# Altium schematic sheet ATSAM4E16EA_AU Main Controller.SchDoc
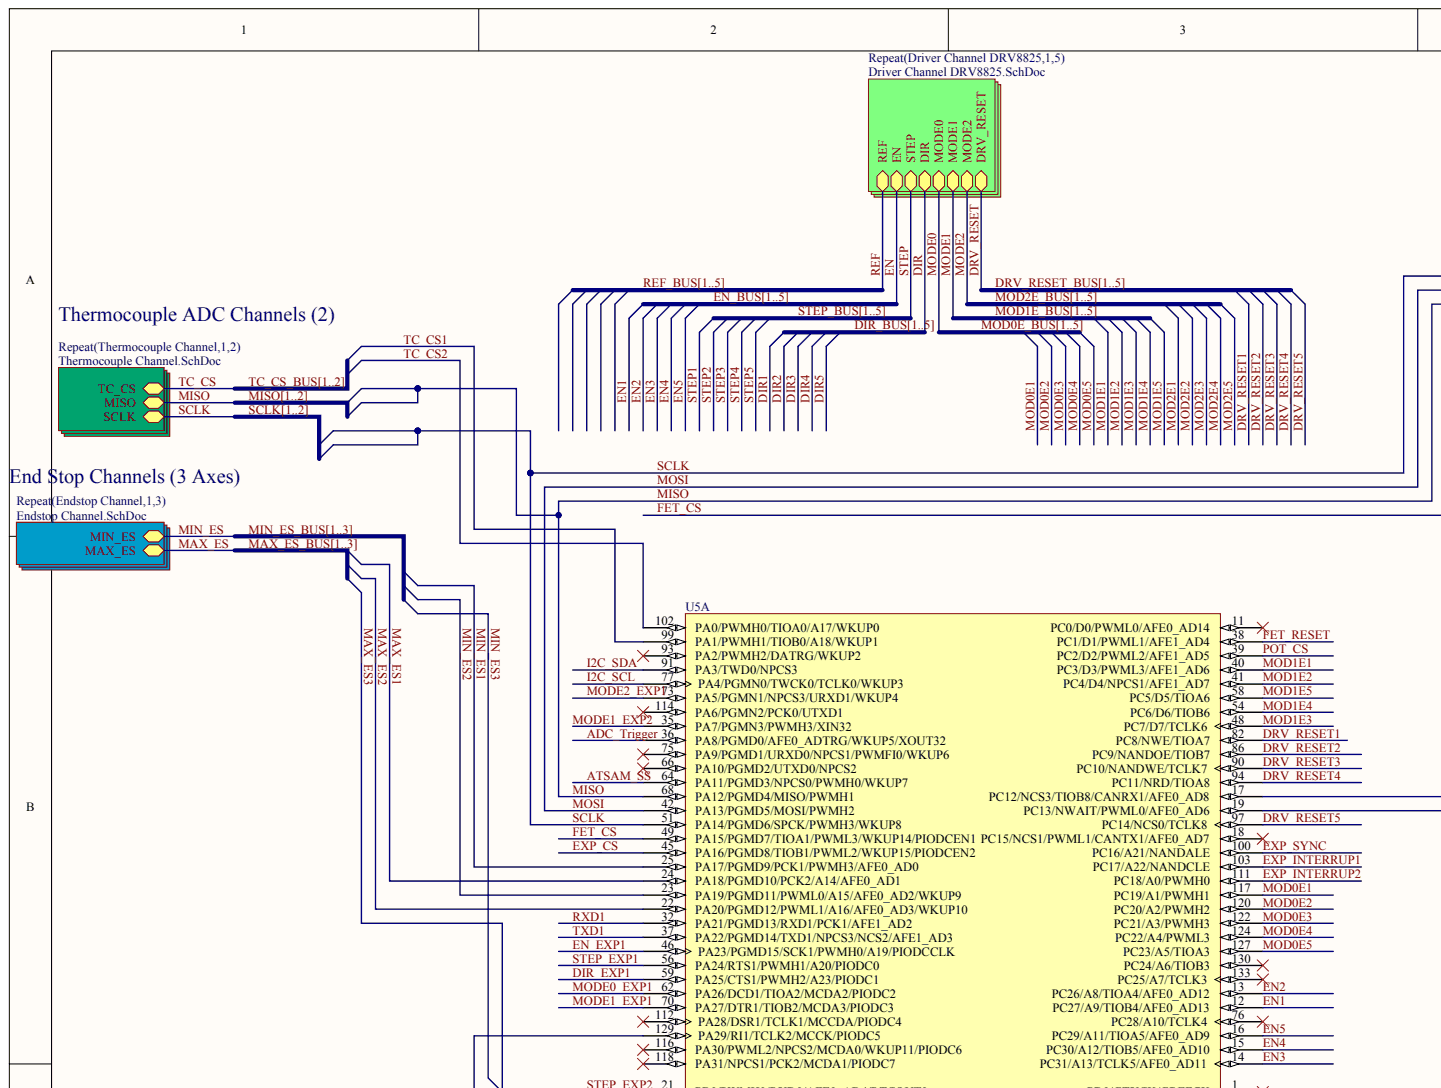
[diagram p0: ATSAM4E16EA_AU Main Controller.SchDoc, top left]
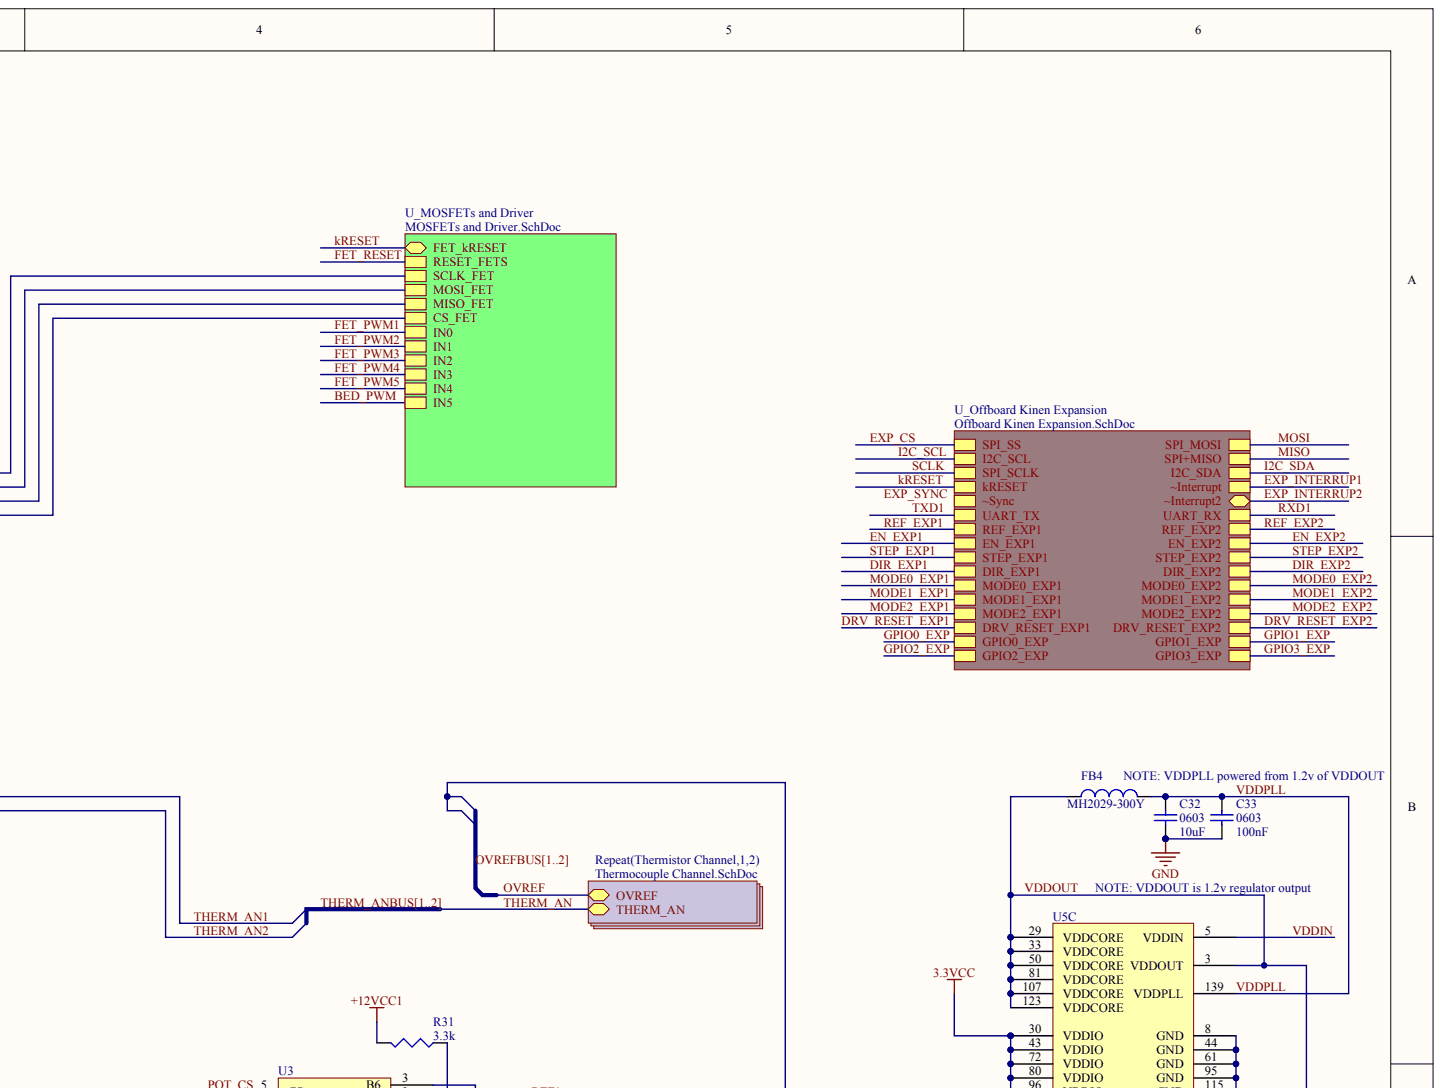
[diagram p1: ATSAM4E16EA_AU Main Controller.SchDoc, top right]
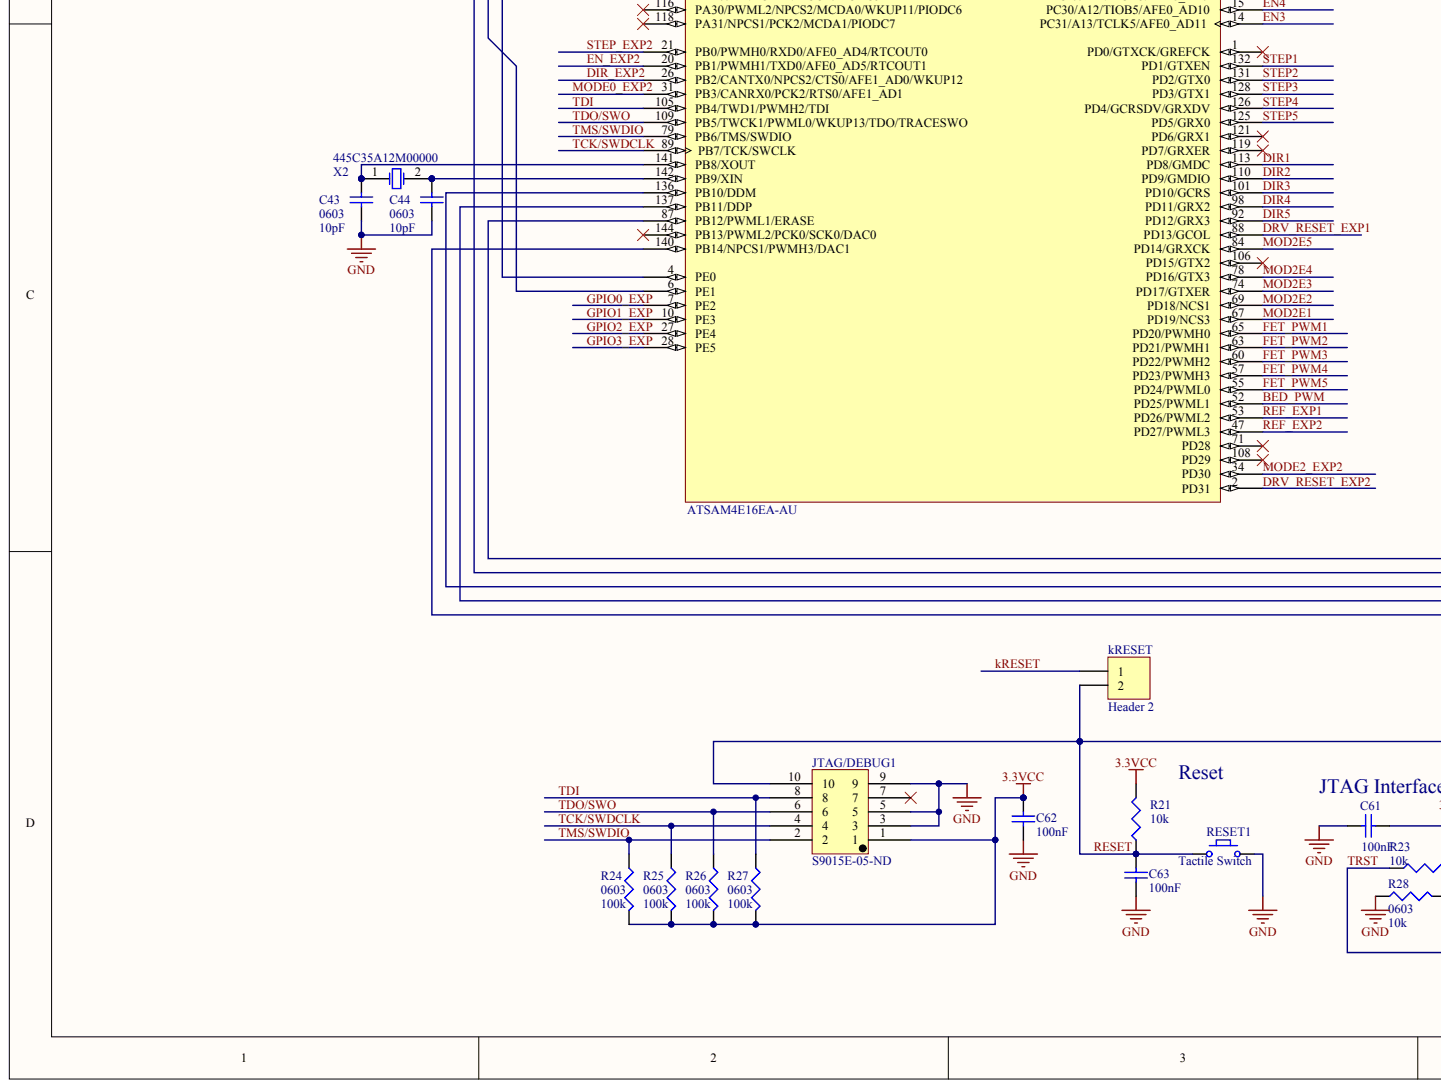
[diagram p2: ATSAM4E16EA_AU Main Controller.SchDoc, bottom left]
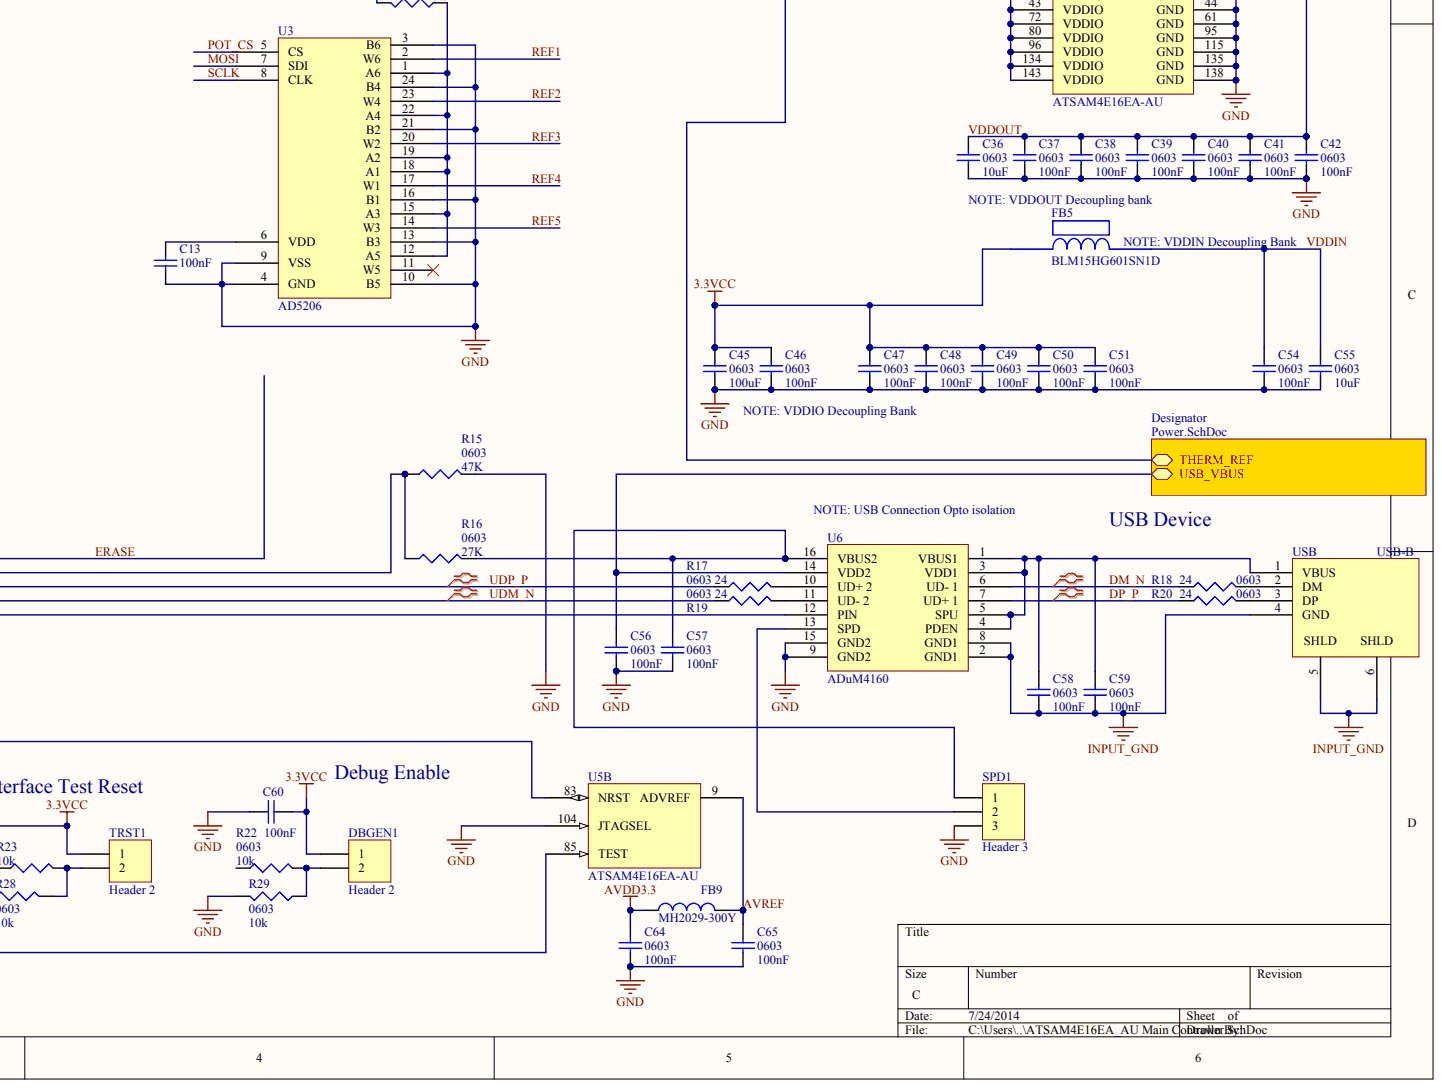
[diagram p3: ATSAM4E16EA_AU Main Controller.SchDoc, bottom right]

## Parts
C13  value 100nF  (hidden comment: Cap Semi)
C32  0603  value 10uF
C33  0603  value 100nF
C36  0603  value 10uF
C37  0603  value 100nF
C38  0603  value 100nF
C39  0603  value 100nF
C40  0603  value 100nF
C41  0603  value 100nF
C42  0603  value 100nF
C43  0603  value 10pF
C44  0603  value 10pF
C45  0603  value 100uF
C46  0603  value 100nF
C47  0603  value 100nF
C48  0603  value 100nF
C49  0603  value 100nF
C50  0603  value 100nF
C51  0603  value 100nF
C54  0603  value 100nF
C55  0603  value 10uF
C56  0603  value 100nF
C57  0603  value 100nF
C58  0603  value 100nF
C59  0603  value 100nF
C60  value 100nF  (hidden comment: Cap Semi)
C61  value 100nF  (hidden comment: Cap Semi)
C62  value 100nF  (hidden comment: Cap Semi)
C63  value 100nF  (hidden comment: Cap Semi)
C64  0603  value 100nF
C65  0603  value 100nF
DBGEN1  Header 2
FB4  MH2029-300Y
FB5  BLM15HG601SN1D
FB9  MH2029-300Y
JTAG/DEBUG1  S9015E-05-ND
kRESET  Header 2
R15  0603  value 47K
R16  0603  value 27K
R17  0603  value 24
R18  0603  value 24
R19  0603  value 24
R20  0603  value 24
R21  value 10k  (hidden comment: Res3)
R22  0603  value 10k
R23  value 10k  (hidden comment: Res3)
R24  0603  value 100k
R25  0603  value 100k
R26  0603  value 100k
R27  0603  value 100k
R28  0603  value 10k
R29  0603  value 10k
R31  value 3.3k  (hidden comment: Res3)
RESET1  Tactile Switch
SPD1  Header 3
TRST1  Header 2
U3  AD5206
U5  ATSAM4E16EA-AU  (parts A,B,C)
U6  ADuM4160
USB  USB-B
X2  445C35A12M00000

## Nets
3.3VCC: C45.2 C46.2 C47.2 C48.2 C49.2 C50.2 C51.2 C60.2 C61.2 C62.2 DBGEN1.1 FB5.1 JTAG/DEBUG1.1 R21.2 R24.2 R25.2 R26.2 R27.2 TRST1.1 U5.30(VDDIO) U5.43(VDDIO) U5.72(VDDIO) U5.80(VDDIO) U5.96(VDDIO) U5.134(VDDIO) U5.143(VDDIO)
+12VCC1: R31.2
AVDD3.3: C64.2 FB9.1
GND: C13.1 C32.1 C33.1 C36.1 C37.1 C38.1 C39.1 C40.1 C41.1 C42.1 C43.1 C44.1 C45.1 C46.1 C47.1 C48.1 C49.1 C50.1 C51.1 C54.1 C55.1 C56.1 C57.1 C60.1 C61.1 C62.1 C63.1 C64.1 C65.1 JTAG/DEBUG1.3 JTAG/DEBUG1.5 JTAG/DEBUG1.9 R15.2 R28.1 R29.2 RESET1.2 SPD1.3 U3.3(B6) U3.4(GND) U3.9(VSS) U3.10(B5) U3.13(B3) U3.16(B1) U3.21(B2) U3.24(B4) U5.8(GND) U5.44(GND) U5.61(GND) U5.95(GND) U5.104(JTAGSEL) U5.115(GND) U5.135(GND) U5.138(GND) U6.9(GND2) U6.15(GND2)
INPUT_GND: C58.1 C59.1 U6.2(GND1) U6.8(GND1) USB.4(GND) USB.5 USB.6
ADC_TRIGGER: U5.36(PA8/PGMD0/AFE0_ADTRG/WKUP5/XOUT32)
ATSAM_SS: U5.64(PA11/PGMD3/NPCS0/PWMH0/WKUP7)
AVREF: C65.2 FB9.2 U5.9(ADVREF)
BED_PWM: U5.52(PD25/PWML1)
DIR1: U5.113(PD8/GMDC)
DIR2: U5.110(PD9/GMDIO)
DIR3: U5.101(PD10/GCRS)
DIR4: U5.98(PD11/GRX2)
DIR5: U5.92(PD12/GRX3)
DIR_EXP1: U5.59(PA25/CTS1/PWMH2/A23/PIODC1)
DIR_EXP2: U5.26(PB2/CANTX0/NPCS2/CTS0/AFE1_AD0/WKUP12)
DM_N: R18.1 U6.6(UD-_1)
DP_P: R20.1 U6.7(UD+_1)
DRV_RESET1: U5.82(PC8/NWE/TIOA7)
DRV_RESET2: U5.86(PC9/NANDOE/TIOB7)
DRV_RESET3: U5.90(PC10/NANDWE/TCLK7)
DRV_RESET4: U5.94(PC11/NRD/TIOA8)
DRV_RESET5: U5.97(PC14/NCS0/TCLK8)
DRV_RESET_EXP1: U5.88(PD13/GCOL)
DRV_RESET_EXP2: U5.2(PD31)
EN1: U5.12(PC27/A9/TIOB4/AFE0_AD13)
EN2: U5.13(PC26/A8/TIOA4/AFE0_AD12)
EN3: U5.14(PC31/A13/TCLK5/AFE0_AD11)
EN4: U5.15(PC30/A12/TIOB5/AFE0_AD10)
EN5: U5.16(PC29/A11/TIOA5/AFE0_AD9)
EN_EXP1: U5.46(PA23/PGMD15/SCK1/PWMH0/A19/PIODCCLK)
EN_EXP2: U5.20(PB1/PWMH1/TXD0/AFE0_AD5/RTCOUT1)
ERASE: U5.87(PB12/PWML1/ERASE)
EXP_CS: U5.45(PA16/PGMD8/TIOB1/PWML2/WKUP15/PIODCEN2)
EXP_INTERRUP1: U5.103(PC17/A22/NANDCLE)
EXP_INTERRUP2: U5.111(PC18/A0/PWMH0)
EXP_SYNC: U5.100(PC16/A21/NANDALE)
FET_CS: U5.49(PA15/PGMD7/TIOA1/PWML3/WKUP14/PIODCEN1)
FET_PWM1: U5.65(PD20/PWMH0)
FET_PWM2: U5.63(PD21/PWMH1)
FET_PWM3: U5.60(PD22/PWMH2)
FET_PWM4: U5.57(PD23/PWMH3)
FET_PWM5: U5.55(PD24/PWML0)
FET_RESET: U5.38(PC1/D1/PWML1/AFE1_AD4)
GPIO0_EXP: U5.7(PE2)
GPIO1_EXP: U5.10(PE3)
GPIO2_EXP: U5.27(PE4)
GPIO3_EXP: U5.28(PE5)
I2C_SCL: U5.77(PA4/PGMN0/TWCK0/TCLK0/WKUP3)
I2C_SDA: U5.91(PA3/TWD0/NPCS3)
KRESET: kRESET.1
MAX_ES1: U5.24(PA18/PGMD10/PCK2/A14/AFE0_AD1)
MAX_ES2: U5.22(PA20/PGMD12/PWML1/A16/AFE0_AD3/WKUP10)
MAX_ES3: U5.4(PE0)
MIN_ES1: U5.25(PA17/PGMD9/PCK1/PWMH3/AFE0_AD0)
MIN_ES2: U5.23(PA19/PGMD11/PWML0/A15/AFE0_AD2/WKUP9)
MIN_ES3: U5.6(PE1)
MISO: U5.68(PA12/PGMD4/MISO/PWMH1)
MOD0E1: U5.117(PC19/A1/PWMH1)
MOD0E2: U5.120(PC20/A2/PWMH2)
MOD0E3: U5.122(PC21/A3/PWMH3)
MOD0E4: U5.124(PC22/A4/PWML3)
MOD0E5: U5.127(PC23/A5/TIOA3)
MOD1E1: U5.40(PC3/D3/PWML3/AFE1_AD6)
MOD1E2: U5.41(PC4/D4/NPCS1/AFE1_AD7)
MOD1E3: U5.48(PC7/D7/TCLK6)
MOD1E4: U5.54(PC6/D6/TIOB6)
MOD1E5: U5.58(PC5/D5/TIOA6)
MOD2E1: U5.67(PD19/NCS3)
MOD2E2: U5.69(PD18/NCS1)
MOD2E3: U5.74(PD17/GTXER)
MOD2E4: U5.78(PD16/GTX3)
MOD2E5: U5.84(PD14/GRXCK)
MODE0_EXP1: U5.62(PA26/DCD1/TIOA2/MCDA2/PIODC2)
MODE0_EXP2: U5.31(PB3/CANRX0/PCK2/RTS0/AFE1_AD1)
MODE1_EXP1: U5.70(PA27/DTR1/TIOB2/MCDA3/PIODC3)
MODE1_EXP2: U5.35(PA7/PGMN3/PWMH3/XIN32)
MODE2_EXP1: U5.73(PA5/PGMN1/NPCS3/URXD1/WKUP4)
MODE2_EXP2: U5.34(PD30)
MOSI: U3.7(SDI) U5.42(PA13/PGMD5/MOSI/PWMH2)
POT_CS: U3.5(CS) U5.39(PC2/D2/PWML2/AFE1_AD5)
REF1: U3.2(W6)
REF2: U3.23(W4)
REF3: U3.20(W2)
REF4: U3.17(W1)
REF5: U3.14(W3)
REF_EXP1: U5.53(PD26/PWML2)
REF_EXP2: U5.47(PD27/PWML3)
RESET: C63.2 JTAG/DEBUG1.10 kRESET.2 R21.1 RESET1.1 U5.83(NRST)
RXD1: U5.32(PA21/PGMD13/RXD1/PCK1/AFE1_AD2)
SCLK: U3.8(CLK) U5.51(PA14/PGMD6/SPCK/PWMH3/WKUP8)
STEP1: U5.132(PD1/GTXEN)
STEP2: U5.131(PD2/GTX0)
STEP3: U5.128(PD3/GTX1)
STEP4: U5.126(PD4/GCRSDV/GRXDV)
STEP5: U5.125(PD5/GRX0)
STEP_EXP1: U5.56(PA24/RTS1/PWMH1/A20/PIODC0)
STEP_EXP2: U5.21(PB0/PWMH0/RXD0/AFE0_AD4/RTCOUT0)
TC_CS1: U5.99(PA1/PWMH1/TIOB0/A18/WKUP1)
TC_CS2: U5.102(PA0/PWMH0/TIOA0/A17/WKUP0)
TCK/SWDCLK: JTAG/DEBUG1.4 R25.1 U5.89(PB7/TCK/SWCLK)
TDI: JTAG/DEBUG1.8 R27.1 U5.105(PB4/TWD1/PWMH2/TDI)
TDO/SWO: JTAG/DEBUG1.6 R26.1 U5.109(PB5/TWCK1/PWML0/WKUP13/TDO/TRACESWO)
THERM_AN1: U5.17(PC12/NCS3/TIOB8/CANRX1/AFE0_AD8)
THERM_AN2: U5.19(PC13/NWAIT/PWML0/AFE0_AD6)
TMS/SWDIO: JTAG/DEBUG1.2 R24.1 U5.79(PB6/TMS/SWDIO)
TRST: R23.1 U5.85(TEST)
TXD1: U5.37(PA22/PGMD14/TXD1/NPCS3/NCS2/AFE1_AD3)
UDM_N: R19.1 U5.137(PB11/DDP)
UDP_P: R17.1 U5.136(PB10/DDM)
VDDIN: C54.2 C55.2 FB5.2 U5.5(VDDIN)
VDDOUT: C36.2 C37.2 C38.2 C39.2 C40.2 C41.2 C42.2 FB4.1 U5.3(VDDOUT) U5.29(VDDCORE) U5.33(VDDCORE) U5.50(VDDCORE) U5.81(VDDCORE) U5.107(VDDCORE) U5.123(VDDCORE)
VDDPLL: C32.2 C33.2 FB4.2 U5.139(VDDPLL)
NetC13_2: C13.2 U3.6(VDD)
NetC43_2: C43.2 U5.141(PB8/XOUT) X2.1
NetC44_2: C44.2 U5.142(PB9/XIN) X2.2
NetC56_2: C56.2 U6.14(VDD2)
NetC57_2: C57.2 R16.2 SPD1.1 U6.16(VBUS2)
NetC58_2: C58.2 C59.2 U6.1(VBUS1) U6.3(VDD1) U6.4(PDEN) U6.5(SPU) USB.1(VBUS)
NetDBGEN1_2: DBGEN1.2 R22.2 R29.1
NetJTAG/DEBUG1_7: JTAG/DEBUG1.7
NetR15_1: R15.1 R16.1 U5.129(PA29/RI1/TCLK2/MCCK/PIODC5)
NetR17_2: R17.2 U6.10(UD+_2)
NetR18_2: R18.2 USB.2(DM)
NetR19_2: R19.2 U6.11(UD-_2)
NetR20_2: R20.2 USB.3(DP)
NetR22_1: R22.1
NetR23_2: R23.2 R28.2 TRST1.2
NetR31_1: R31.1 U3.1(A6) U3.12(A5) U3.15(A3) U3.18(A1) U3.19(A2) U3.22(A4)
NetSPD1_2: SPD1.2 U6.13(SPD)
NetU3_11: U3.11(W5)
NetU5_1: U5.1(PD0/GTXCK/GREFCK)
NetU5_11: U5.11(PC0/D0/PWML0/AFE0_AD14)
NetU5_18: U5.18(PC15/NCS1/PWML1/CANTX1/AFE0_AD7)
NetU5_66: U5.66(PA10/PGMD2/UTXD0/NPCS2)
NetU5_71: U5.71(PD28)
NetU5_75: U5.75(PA9/PGMD1/URXD0/NPCS1/PWMFI0/WKUP6)
NetU5_76: U5.76(PC28/A10/TCLK4)
NetU5_93: U5.93(PA2/PWMH2/DATRG/WKUP2)
NetU5_106: U5.106(PD15/GTX2)
NetU5_108: U5.108(PD29)
NetU5_112: U5.112(PA28/DSR1/TCLK1/MCCDA/PIODC4)
NetU5_114: U5.114(PA6/PGMN2/PCK0/UTXD1)
NetU5_116: U5.116(PA30/PWML2/NPCS2/MCDA0/WKUP11/PIODC6)
NetU5_118: U5.118(PA31/NPCS1/PCK2/MCDA1/PIODC7)
NetU5_119: U5.119(PD7/GRXER)
NetU5_121: U5.121(PD6/GRX1)
NetU5_130: U5.130(PC24/A6/TIOB3)
NetU5_133: U5.133(PC25/A7/TCLK3)
NetU5_140: U5.140(PB14/NPCS1/PWMH3/DAC1) U6.12(PIN)
NetU5_144: U5.144(PB13/PWML2/PCK0/SCK0/DAC0)
DIR:
DIR_BUS1:  [also labelled DIR_BUS]
DIR_BUS2:  [also labelled DIR_BUS]
DIR_BUS3:  [also labelled DIR_BUS]
DIR_BUS4:  [also labelled DIR_BUS]
DIR_BUS5:  [also labelled DIR_BUS]
DRV_RESET:
DRV_RESET_BUS1:  [also labelled DRV_RESET_BUS]
DRV_RESET_BUS2:  [also labelled DRV_RESET_BUS]
DRV_RESET_BUS3:  [also labelled DRV_RESET_BUS]
DRV_RESET_BUS4:  [also labelled DRV_RESET_BUS]
DRV_RESET_BUS5:  [also labelled DRV_RESET_BUS]
EN:
EN_BUS1:  [also labelled EN_BUS]
EN_BUS2:  [also labelled EN_BUS]
EN_BUS3:  [also labelled EN_BUS]
EN_BUS4:  [also labelled EN_BUS]
EN_BUS5:  [also labelled EN_BUS]
MAX_ES:
MAX_ES_BUS1:  [also labelled MAX_ES_BUS]
MAX_ES_BUS2:  [also labelled MAX_ES_BUS]
MAX_ES_BUS3:  [also labelled MAX_ES_BUS]
MIN_ES:
MIN_ES_BUS1:  [also labelled MIN_ES_BUS]
MIN_ES_BUS2:  [also labelled MIN_ES_BUS]
MIN_ES_BUS3:  [also labelled MIN_ES_BUS]
MISO1:  [also labelled MISO]
MISO2:  [also labelled MISO]
MOD0E_BUS1:  [also labelled MOD0E_BUS]
MOD0E_BUS2:  [also labelled MOD0E_BUS]
MOD0E_BUS3:  [also labelled MOD0E_BUS]
MOD0E_BUS4:  [also labelled MOD0E_BUS]
MOD0E_BUS5:  [also labelled MOD0E_BUS]
MOD1E_BUS1:  [also labelled MOD1E_BUS]
MOD1E_BUS2:  [also labelled MOD1E_BUS]
MOD1E_BUS3:  [also labelled MOD1E_BUS]
MOD1E_BUS4:  [also labelled MOD1E_BUS]
MOD1E_BUS5:  [also labelled MOD1E_BUS]
MOD2E_BUS1:  [also labelled MOD2E_BUS]
MOD2E_BUS2:  [also labelled MOD2E_BUS]
MOD2E_BUS3:  [also labelled MOD2E_BUS]
MOD2E_BUS4:  [also labelled MOD2E_BUS]
MOD2E_BUS5:  [also labelled MOD2E_BUS]
MODE0:
MODE1:
MODE2:
N00126:
N00127:
N00128:
N00129:
N00130:
OVREF:
OVREFBUS1:  [also labelled OVREFBUS]
OVREFBUS2:  [also labelled OVREFBUS]
REF:
REF_BUS1:  [also labelled REF_BUS]
REF_BUS2:  [also labelled REF_BUS]
REF_BUS3:  [also labelled REF_BUS]
REF_BUS4:  [also labelled REF_BUS]
REF_BUS5:  [also labelled REF_BUS]
SCLK1:  [also labelled SCLK]
SCLK2:  [also labelled SCLK]
STEP:
STEP_BUS1:  [also labelled STEP_BUS]
STEP_BUS2:  [also labelled STEP_BUS]
STEP_BUS3:  [also labelled STEP_BUS]
STEP_BUS4:  [also labelled STEP_BUS]
STEP_BUS5:  [also labelled STEP_BUS]
TC_CS:
TC_CS_BUS1:  [also labelled TC_CS_BUS]
TC_CS_BUS2:  [also labelled TC_CS_BUS]
THERM_AN:
THERM_ANBUS1:  [also labelled THERM_ANBUS]
THERM_ANBUS2:  [also labelled THERM_ANBUS]
THERM_REF:
~SYNC:

## Library data of the parts
FB4 FB9 = (no symbol name): Code_IEC: 1005; Published: 8-Jun-2000; LatestRevisionDate: 17-Jul-2002; LatestRevisionNote: Re-released for DXP Platform.; PackageReference: 0402-A; Code_JEITA: 0402; Publisher: Altium Limited
C13 C32 C33 C36-C51 C54-C65 DBGEN1 FB5 JTAG/DEBUG1 kRESET R15-R29 R31 RESET1 SPD1 TRST1 U3 U5 U6 USB X2 = (no symbol name)
  C13 C32 C33 C36-C51 C54-C65: Published: 8-Jun-2000; LatestRevisionDate: 29-May-2009; LatestRevisionNote: IPC-7351 Footprint Added.; PackageReference: C1206; PackageDescription: Chip Capacitor
  DBGEN1 kRESET SPD1 TRST1: LatestRevisionDate: 17-Jul-2002; LatestRevisionNote: Re-released for DXP Platform.
  R15-R29 R31: Published: 8-Jun-2000; LatestRevisionDate: 29-May-2009; LatestRevisionNote: IPC-7351 Footprint Added.; PackageReference: J1-0603; PackageDescription: Chip Resistor
  X2: Published: 8-Jun-2000; LatestRevisionDate: 17-Jul-2002; LatestRevisionNote: Re-released for DXP Platform.; PackageReference: R38; PackageDescription: Crystal, Thru-Hole; 2 Leads; Body 3.1 x 8.2 mm (Dia.xH)
  C13 C32 C33 C36-C51 C54-C65 DBGEN1 kRESET R15-R29 R31 SPD1 TRST1 X2: Publisher: Altium Limited
